# Altium schematic sheet ASV4-2 Acoustics Board.SchDoc("ASV4-2 Acoustics Board")
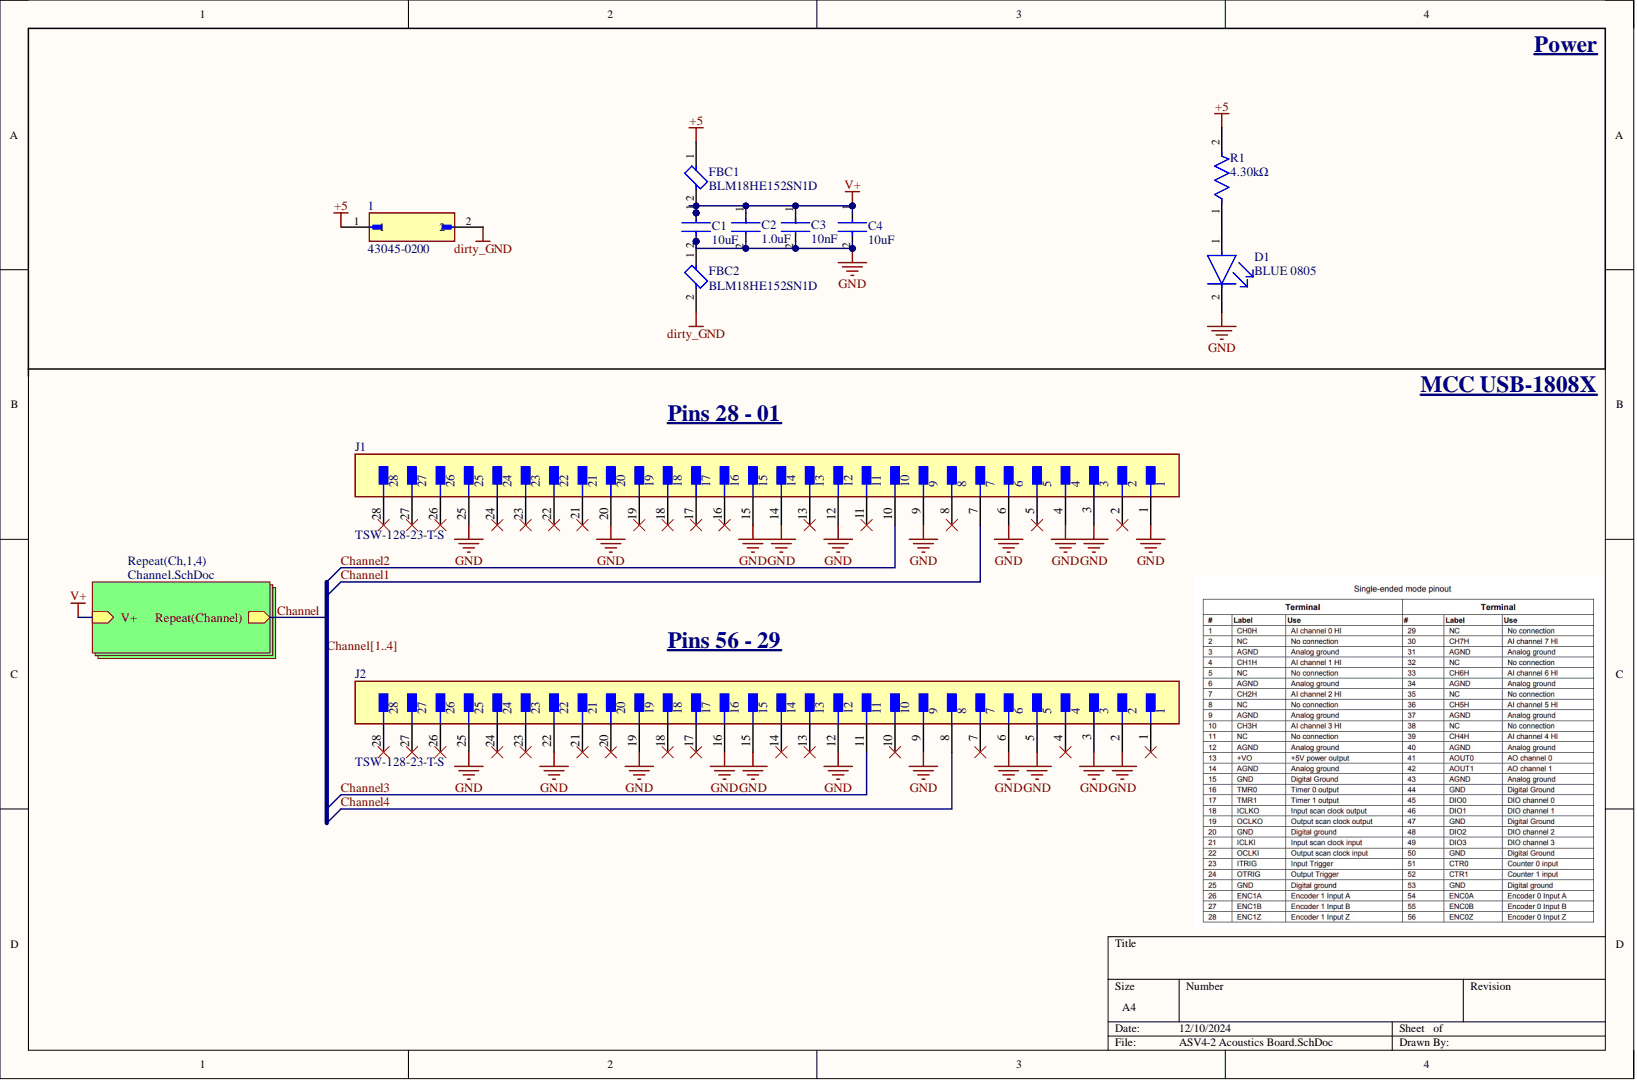
[diagram p0: ASV4-2 Acoustics Board.SchDoc]

## Parts
1  43045-0200
C1  10uF
C2  1.0uF
C3  10nF
C4  10uF
D1  BLUE 0805
FBC1  BLM18HE152SN1D
FBC2  BLM18HE152SN1D
J1  TSW-128-23-T-S
J2  TSW-128-23-T-S
R1  4.30kΩ

## Nets
+5: 1.1 FBC1.1 R1.2
dirty_GND: 1.2 FBC2.2
GND: C1.2 C2.2 C3.2 C4.2 D1.2 FBC2.1 J1.1 J1.3 J1.4 J1.6 J1.9 J1.12 J1.14 J1.15 J1.20 J1.25 J2.2 J2.3 J2.5 J2.6 J2.9 J2.12 J2.15 J2.16 J2.19 J2.22 J2.25
V+: C1.1 C2.1 C3.1 C4.1 FBC1.2
Channel1: J1.7  [also labelled Channel]
Channel2: J1.10  [also labelled Channel]
Channel3: J2.11  [also labelled Channel]
Channel4: J2.8  [also labelled Channel]
NetD1_1: D1.1 R1.1
NetJ1_2: J1.2
NetJ1_5: J1.5
NetJ1_8: J1.8
NetJ1_11: J1.11
NetJ1_13: J1.13
NetJ1_16: J1.16
NetJ1_17: J1.17
NetJ1_18: J1.18
NetJ1_19: J1.19
NetJ1_21: J1.21
NetJ1_22: J1.22
NetJ1_23: J1.23
NetJ1_24: J1.24
NetJ1_26: J1.26
NetJ1_27: J1.27
NetJ1_28: J1.28
NetJ2_1: J2.1
NetJ2_4: J2.4
NetJ2_7: J2.7
NetJ2_10: J2.10
NetJ2_13: J2.13
NetJ2_14: J2.14
NetJ2_17: J2.17
NetJ2_18: J2.18
NetJ2_20: J2.20
NetJ2_21: J2.21
NetJ2_23: J2.23
NetJ2_24: J2.24
NetJ2_26: J2.26
NetJ2_27: J2.27
NetJ2_28: J2.28

## Library data of the parts
1 = CMP-075-000000-1: Connector Header Through Hole, Right Angle 2 position -; MF: Molex; Check_prices: https://www.snapeda.com/parts/43045-0200/Molex/view-part/?ref=eda; Component Kind: Standard; Component Type: Standard; Design Item ID: 43045-0200; Digi-Key_Part_Number: WM1813-ND; MP: 43045-0200; Package: None; Pin Count: 2; Purchase-URL: https://pricing.snapeda.com/search/part/43045-0200/?ref=eda; SnapEDA_Link: https://www.snapeda.com/parts/43045-0200/Molex/view-part/?ref=snap; Source: 43045-0200.SchLib; Library Name: BumbleBee Autonomous Systems - NUS
C1 C4 = CMP-2000-04979-3: Multilayer Ceramic Capacitors 10μF ±10% 10V X5R SMD 0603; Capacitance: 10uF; Case Code (Imperial): 0603; Case Code (Metric): 1608; Case/Package: 0603; Component Kind: Standard; Construction: Flat; Depth: 0.8mm; Dielectric: X5R; Dielectric Material: Ceramic; Height: 0.8mm; Lead Free: Lead Free; Length: 1.6mm; Library Name: Altium Content Vault; Max Operating Temperature: 85°C; Min Operating Temperature: -55°C; Mount: Surface Mount; Number of Pins: 2; Package Quantity: 1; Packaging: Tape and Reel; Radiation Hardening: No; REACH SVHC: No SVHC; Resistor Type: Ceramic; RoHS Compliant: Yes; Series: C; Termination: SMD/SMT; Thickness: 0.035inch; Tolerance: 10%; Voltage: 10V; Voltage Rating: 10V; Voltage Rating (DC): 10V; Weight: 7.1E-05oz; Width: 0.8mm
C2 = CMP-03422-000924-1: CAP CER 1UF 16V X7R 0603; Manufacturer: YAGEO; Manufacturer Part Number: CC0603KRX7R7BB105; Material: Ceramic; Temperature Coefficient: 15%; Tolerance: 0.1; Automotive: No; Capacitance: 1 uF; Category: Cap; Component Kind: Standard; ComponentLink2Description: Datasheet; ComponentLink2URL: http://www.yageo.com/documents/recent/UPY-GPHC_X7R_6.3V-to-50V_18.pdf; Device Class L1: Passive Components; Device Class L2: Capacitors; Device Class L3: Ceramic Capacitors; Digikey Description: CAP CER 1UF 16V X7R 0603; Footprint Url: http://www.yageo.com/exep/pages/download/literatures/UPY-C_GEN_24.pdf; Height: 0.9mm; Lead Free: Yes; Library Name: Altium Content Vault; Mouser Description: Multilayer Ceramic Capacitor, CC Series, 1 - F, - 10%, X7R, 16 V, 0603 [1608 Metric]; Octopart: https://octopart.com/cc0603krx7r7bb105-yageo-42271928; Package: CC0603-DA; RoHS: TRUE; Temperature: -55°C to +125°C; Temperature Characteristic: X7R; Temperature Range High: +125°C; Temperature Range Low: -55°C; Value: 1 uF; Voltage: 16 V; Voltage Rating: 16 V
C3 = CMP-2100-03600-2: CAP CER 10000PF 50V X7R 0603; Manufacturer: YAGEO; Manufacturer Part Number: CC0603KRX7R9BB103; Material: Ceramic; Temperature Coefficient: 15%; Tolerance: 0.1; Automotive: No; Capacitance: 10 nF; Category: Cap; Component Kind: Standard; ComponentLink2Description: Datasheet; ComponentLink2URL: http://www.yageo.com/documents/recent/UPY-GPHC_X7R_6.3V-to-50V_18.pdf; Device Class L1: Passive Components; Device Class L2: Capacitors; Device Class L3: Ceramic Capacitors; Digikey Description: CAP CER 10000PF 50V X7R 0603; Footprint Url: http://www.yageo.com/exep/pages/download/literatures/UPY-C_GEN_24.pdf; Height: 0.9mm; Lead Free: Yes; Library Name: Altium Content Vault; Mouser Description: Multilayer Ceramic Capacitor, CC Series, 0.01 - F, - 10%, X7R, 50 V, 0603 [1608 Metric]; Octopart: https://octopart.com/cc0603krx7r9bb103-yageo-192938; Package: CC0603-DA; RoHS: TRUE; Temperature: -55°C to +125°C; Temperature Characteristic: X7R; Temperature Range High: +125°C; Temperature Range Low: -55°C; Value: 10 nF; Voltage: 50 V; Voltage Rating: 50 V
D1 = CMP-35283-000004-1: LED BLUE CLEAR 0805 SMD; Dominant Wavelength: 470nm; Forward Current: 20mA; Forward Voltage: 3.3V; Lens Color: Colorless; Lens Style: Rectangular; Luminous Intensity: 115mcd; Power Rating: 100mW; Viewing Angle: 130°; Case Code (Imperial): 0805; Case Code (Metric): 2012; Case/Package: 0805; Color: Blue; Component Kind: Standard; Height: 1.1mm; Illumination Color: Blue; Lead Free: Lead Free; Length: 2mm; Lens Transparency: Clear; Library Name: Altium Content Vault; Max Operating Temperature: 80°C; Min Operating Temperature: -30°C; Mount: Surface Mount; Number of Pins: 2; Package Quantity: 3000; Packaging: Tape and Reel; Test Current: 20mA; Wavelength: 470nm; Width: 1.2mm
FBC1 FBC2 = CMP-0686-00368-3: Chip Ferrite Bead, 0603, 1500Ω @ 100MHz, 0.5Ω, 25%, 500mA; DC Resistance (DCR): 500mR; Impedance: 1.5kR; Number of Circuits: 1; Case Code (Imperial): 0603; Case Code (Metric): 1608; Case/Package: 0603; Component Kind: Standard; Core Material: Ferrite; Current Rating: 500mA; DC Current: 500mA; Depth: 0.8mm; Height: 0.8mm; Lead Free: Lead Free; Length: 1.6mm; Library Name: Altium Content Vault; Material: Ferrite; Max Current Rating: 500mA; Max DC Current: 500mA; Max Operating Temperature: 125°C; Military Standard: Not; Min Operating Temperature: -55°C; Number of Lines: 1; Number of Pins: 2; Package Quantity: 1; Packaging: Tape and Reel; Radiation Hardening: No; REACH SVHC: No SVHC; Termination: SMD/SMT; Test Frequency: 100MHz; Tolerance: 25%; Width: 0.8mm
J1 J2 = CMP-02766-001385-1: CONN HEADER VERT 28POS 2.54MM; Connector Type: Male Pin; Gender: Male; Number of Contacts: 28; Pitch: 0.1inch; Color: Black; Component Kind: Standard; Contact Material: Bronze; Contact Mating Length: 0.23inch; Contact Plating: Tin; Contact Type: Male Pin; Height: 2.54mm; Housing Color: Black; Housing Material: Plastic; Lead Free: Lead Free; Length: 71.1mm; Library Name: Altium Content Vault; Mount: Through Hole; Number of Positions: 28; Number of Rows: 1; Package Quantity: 1; Packaging: Bulk; Radiation Hardening: No; REACH SVHC: No SVHC; RoHS Compliant: Yes; Series: TSW; Termination: Solder; Width: 2.54mm
R1 = CMP-1012-00581-3: RES SMD 4.3K OHM 1% 1/10W 0603; Max Power Dissipation: 100mW; Resistance: 4.3kR; Temperature Coefficient: 100ppm/°C; Voltage Rating: 75V; Case Code (Imperial): 0603; Case Code (Metric): 1608; Case/Package: 0603; Component Kind: Standard; Construction: Rectangular; Contact Plating: Tin; Depth: 0.8mm; Features: Automotive AEC-Q200; Height: 0.022inch; Lead Free: Lead Free; Length: 1.6mm; Library Name: Altium Content Vault; Max Operating Temperature: 155°C; Military Standard: Not; Min Operating Temperature: -55°C; Mount: Surface Mount; Number of Terminations: 2; Package Quantity: 1; Packaging: Tape and Reel; Power Rating: 100mW; REACH SVHC: No SVHC; Resistance Tolerance: 1%; Resistor Type: Thick Film; RoHS Compliant: Yes; Series: ERJ; Termination: SMD/SMT; Tolerance: 1%; Voltage Rating (DC): 75V; Width: 0.031inch
